# Altium schematic sheet ESP8266_PCB.SchDoc(ESP8266_PCB)
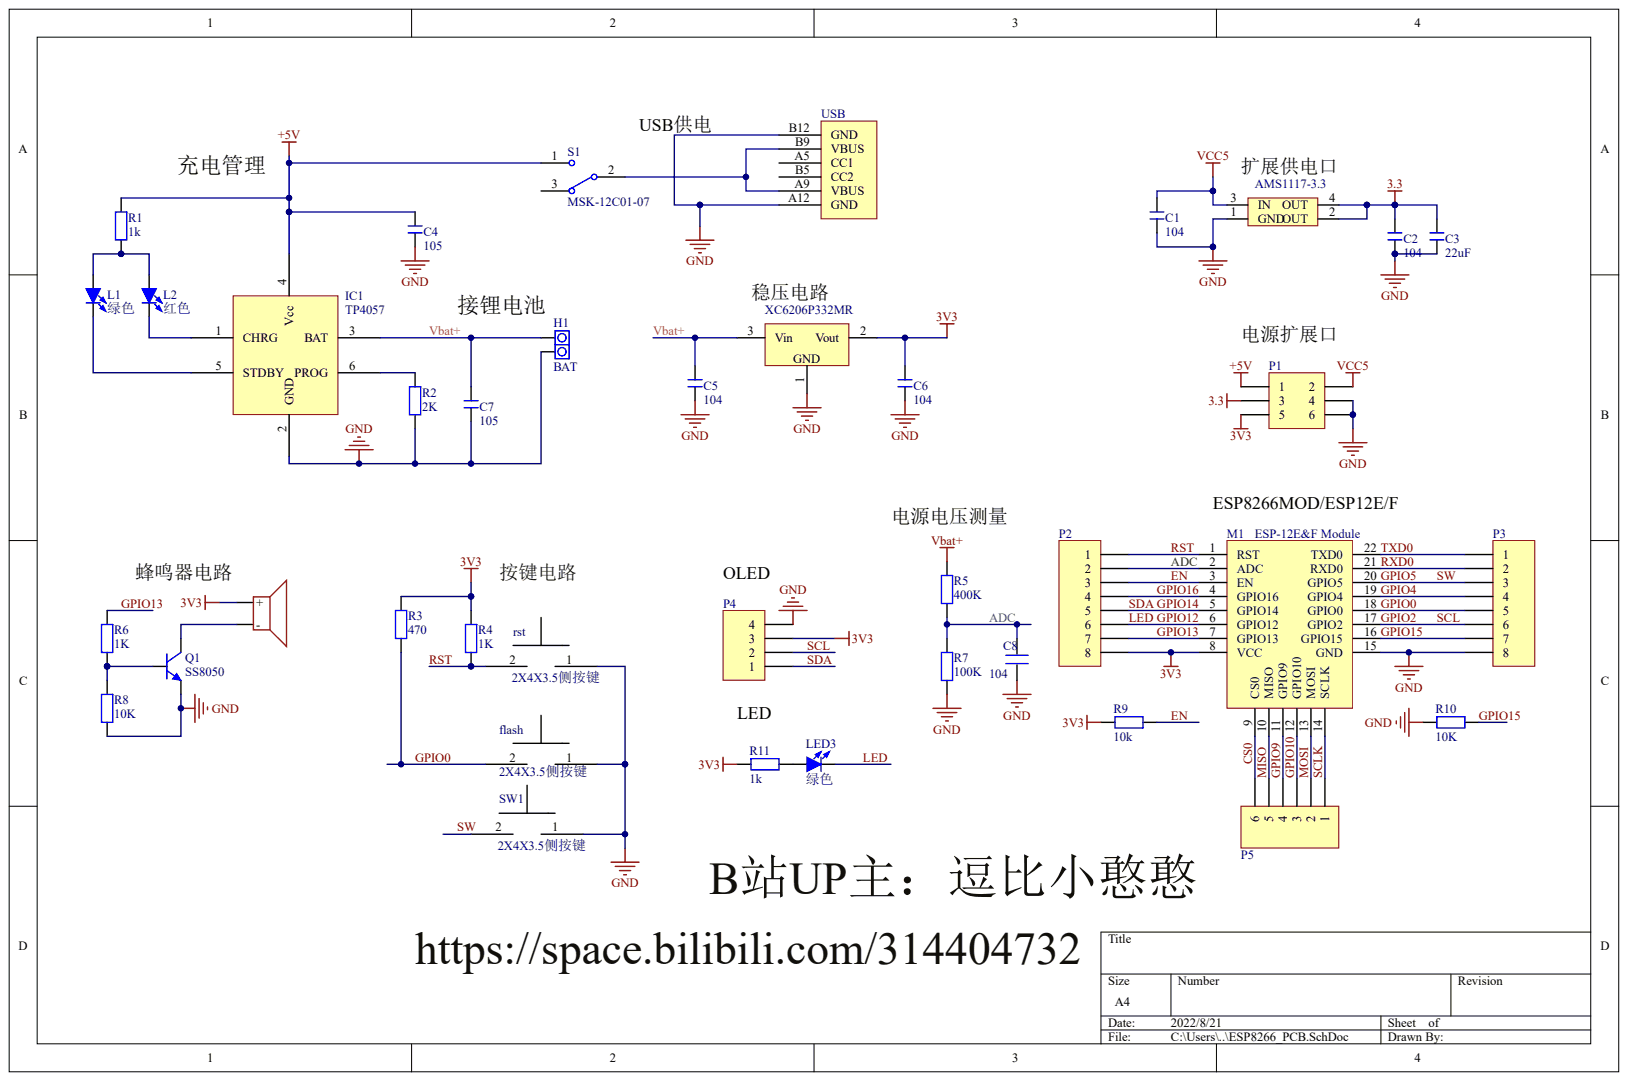
[diagram p0: ESP8266_PCB.SchDoc]

## Parts
beep  Beep-5020  (value not drawn)
C1  104
C2  104
C3  22uF
C4  105
C5  104
C6  104
C7  105
C8  104
flash  2X4X3.5侧按键
H1  BAT
IC1  TP4057
L1  绿色
L2  红色
LED3  绿色
M1  ESP-12E&F Module
P1  Header 3X2  (value not drawn)
P2  Header 8  (value not drawn)
P3  Header 8  (value not drawn)
P4  Header 4  (value not drawn)
P5  Header 6  (value not drawn)
Q1  SS8050
R1  1k
R2  2K
R3  470
R4  1K
R5  400K
R6  1K
R7  100K
R8  10K
R9  10k
R10  10K
R11  1k
rst  2X4X3.5侧按键
S1  MSK-12C01-07
SW1  2X4X3.5侧按键
U1  AMS1117-3.3
USB  TYPE-C-6P  (value not drawn)
V1  XC6206P332MR

## Nets
3.3: C2.1 C3.2 P1.3 U1.2(OUT) U1.4(OUT)
3V3: beep.1 C6.2 M1.8(VCC) P1.5 P2.8 P4.3 R3.1 R4.2 R9.1 R11.2 V1.2
+5V: C4.2 IC1.4(Vcc) P1.1 R1.2 S1.1
GND: C1.1 C2.2 C3.1 C4.1 C5.1 C6.1 C7.1 C8.1 flash.1 H1.2 IC1.2(GND) M1.15(GND) P1.4 P1.6 P3.8 P4.4 Q1.2 R2.1 R7.1 R8.1 R10.2 rst.1 SW1.1 U1.1(GND) USB.A12(GND) USB.B12(GND) V1.1
VCC5: C1.2 P1.2 U1.3(IN)
ADC: C8.2 M1.2(ADC) P2.2 R5.1 R7.2
CS0: M1.9(CS0) P5.6
EN: M1.3(EN) P2.3 R9.2
GPIO0: flash.2 M1.18(GPIO0) P3.5 R3.2
GPIO2: M1.17(GPIO2) P3.6 P4.2
GPIO4: M1.19(GPIO4) P3.4
GPIO5: M1.20(GPIO5) P3.3 SW1.2
GPIO9: M1.11(GPIO9) P5.4
GPIO10: M1.12(GPIO10) P5.3
GPIO12: LED3.2 M1.6(GPIO12) P2.6
GPIO13: M1.7(GPIO13) P2.7 R6.2
GPIO14: M1.5(GPIO14) P2.5 P4.1
GPIO15: M1.16(GPIO15) P3.7 R10.1
GPIO16: M1.4(GPIO16) P2.4
MISO: M1.10(MISO) P5.5
MOSI: M1.13(MOSI) P5.2
RST: M1.1(RST) P2.1 R4.1 rst.2
RXD0: M1.21(RXD0) P3.2
SCLK: M1.14(SCLK) P5.1
TXD0: M1.22(TXD0) P3.1
VBAT+: C5.2 C7.2 H1.1 IC1.3(BAT) R5.2 V1.3
Netbeep_2: beep.2 Q1.3
NetIC1_1: IC1.1(CHRG) L2.2
NetIC1_5: IC1.5(STDBY) L1.2
NetIC1_6: IC1.6(PROG) R2.2
NetL1_1: L1.1 L2.1 R1.1
NetLED3_1: LED3.1 R11.1
NetQ1_1: Q1.1 R6.1 R8.2
NetS1_2: S1.2 USB.A9(VBUS) USB.B9(VBUS)

Not connected: S1 3; USB A5(CC1) B5(CC2)

## Library data of the parts
C1-C3 C5 C6 = (no symbol name): BOM名称: 无极性贴片电容; 版权归属: 源创客; 材质: X7R; 学习资源: www.ooocke.com
C4 C7 = (no symbol name): BOM名称: 无极性贴片电容; 版权归属: 源创客; 学习资源: www.ooocke.com
H1 = (no symbol name): BOM名称: 引线焊盘; 版权归属: 源创客; 学习资源: www.ooocke.com
L1 L2 LED3 = (no symbol name): BOM名称: 贴片LED; 版权归属: 源创客; 学习资源: www.ooocke.com
Q1 = (no symbol name): BOM名称: 高频放大-NPN型; 版权归属: 源创客; 属性: 40V 1.5A 1W 100MHZ; 学习资源: www.ooocke.com
R1-R11 = (no symbol name): BOM名称: 贴片电阻; 版权归属: 源创客; 学习资源: www.ooocke.com
USB = (no symbol name): BOM名称: USB-C型母接口; 版权归属: 源创客; 学习资源: www.ooocke.com
V1 = (no symbol name): BOM名称: 低压差线性稳压芯片; 版权归属: 源创客; 学习资源: www.ooocke.com
beep C8 flash IC1 M1 P1-P5 rst S1 SW1 U1 = (no symbol name)
  P1-P5: LatestRevisionDate: 17-Jul-2002; LatestRevisionNote: Re-released for DXP Platform.; Publisher: Altium Limited
